# Altium schematic sheet Display.SchDoc
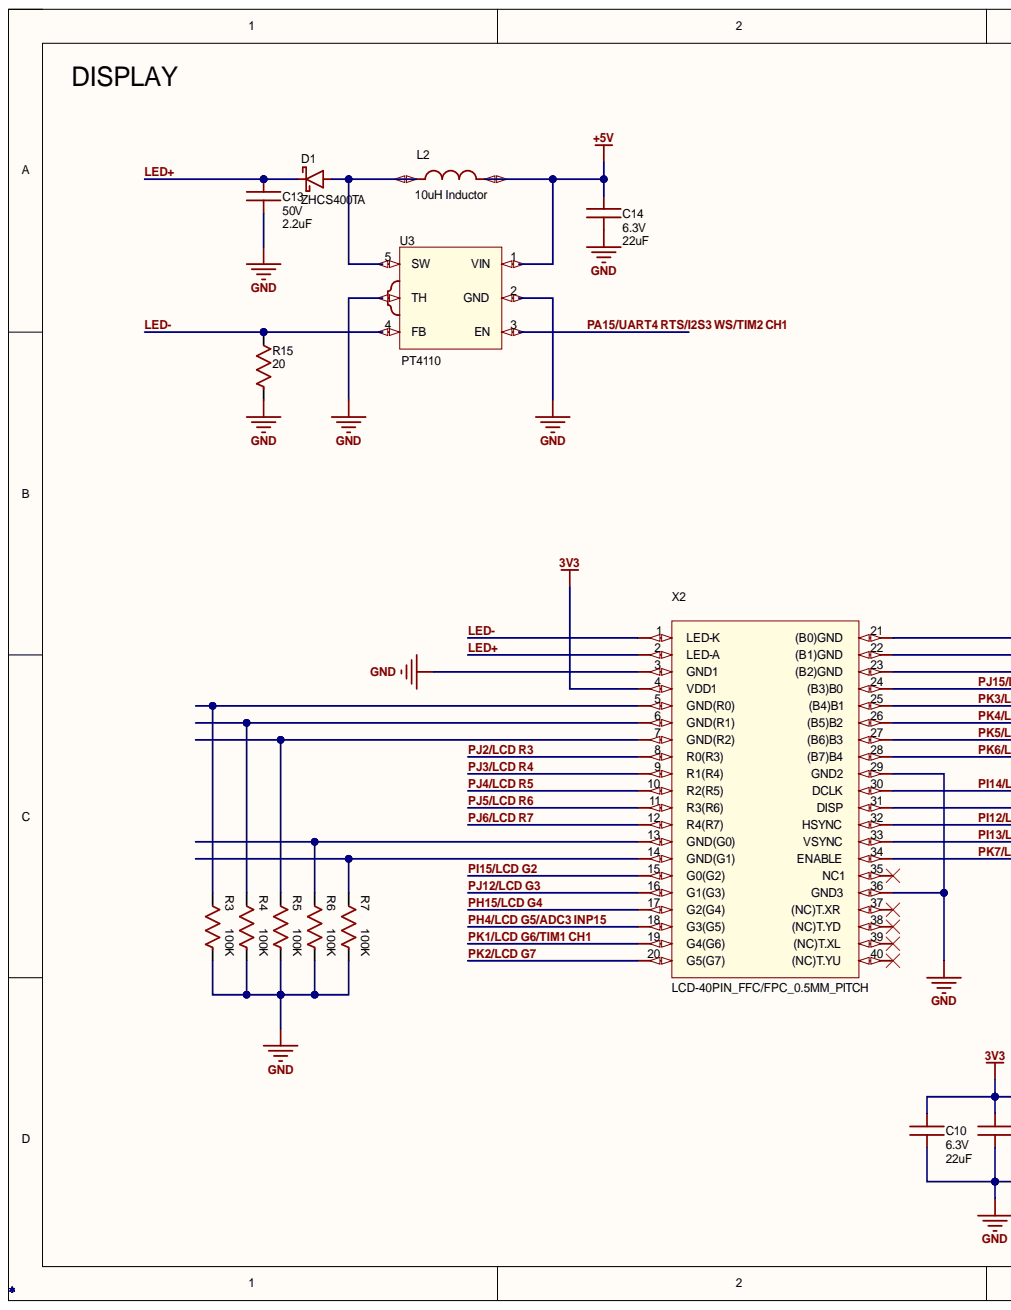
[diagram p0: Display.SchDoc, left]
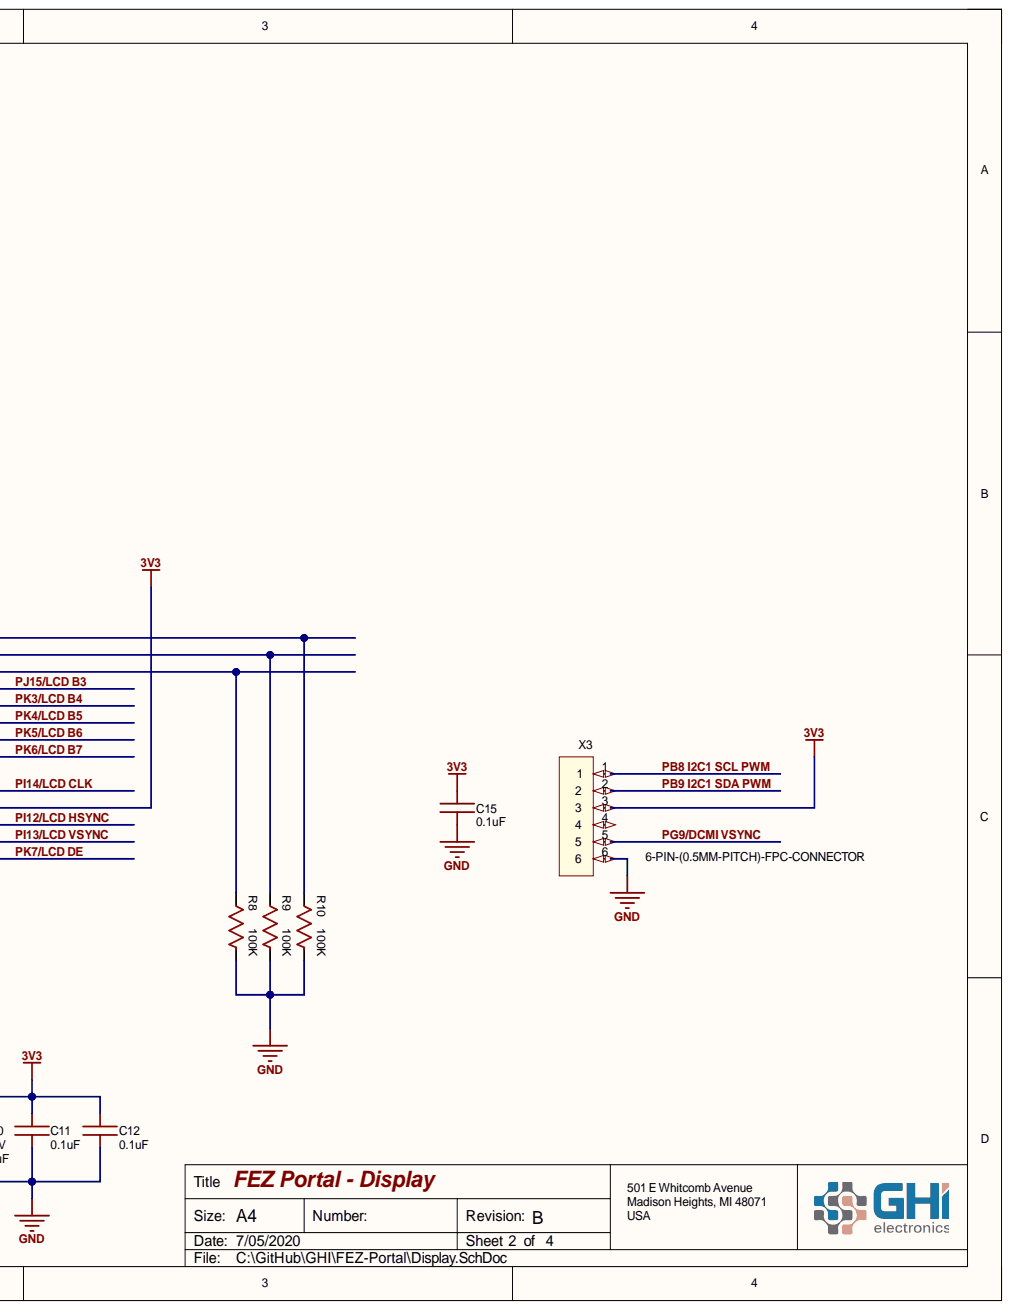
[diagram p1: Display.SchDoc, right]

## Parts
C10  value 22uF  Capacitance: 22uF  Voltage: 6.3V  [C0805 L]  (hidden comment: 0805 Cap 22uF)
C11  value 0.1uF  Capacitance: 0.1uF  [C0603 (1608 Metric)]  (hidden comment: 0603 Cap 0.1uF)
C12  value 0.1uF  Capacitance: 0.1uF  [C0603 (1608 Metric)]  (hidden comment: 0603 Cap 0.1uF)
C13  value 2.2uF  Capacitance: 2.2uF  Voltage: 50V  [C0805]  (hidden comment: 0805 Cap 2.2uF)
C14  value 22uF  Capacitance: 22uF  Voltage: 6.3V  [C0805]  (hidden comment: 0805 Cap 22uF)
C15  value 0.1uF  Capacitance: 0.1uF  [C0603 (1608 Metric)]  (hidden comment: 0603 Cap 0.1uF)
D1  ZHCS400TA  [SOD-323]
L2  (no value)  [NRS(TAIYO.YUDEN)]
R3  value 100K  Resistance: 100K  [R0603 (1608 Metric)]  (hidden comment: 0603 Res 100K)
R4  value 100K  Resistance: 100K  [R0603 (1608 Metric)]  (hidden comment: 0603 Res 100K)
R5  value 100K  Resistance: 100K  [R0603 (1608 Metric)]  (hidden comment: 0603 Res 100K)
R6  value 100K  Resistance: 100K  [R0603 (1608 Metric)]  (hidden comment: 0603 Res 100K)
R7  value 100K  Resistance: 100K  [R0603 (1608 Metric)]  (hidden comment: 0603 Res 100K)
R8  value 100K  Resistance: 100K  [R0603 (1608 Metric)]  (hidden comment: 0603 Res 100K)
R9  value 100K  Resistance: 100K  [R0603 (1608 Metric)]  (hidden comment: 0603 Res 100K)
R10  value 100K  Resistance: 100K  [R0603 (1608 Metric)]  (hidden comment: 0603 Res 100K)
R15  value 20  [R0603 (1608 Metric)]  (hidden comment: 0603 20)
U3  (no value)  [SOT89-5(DIODES)]
X2  LCD-40PIN_FFC/FPC_0.5MM_PITCH  [KISD04-40_T1_E]
X3  6-PIN-(0.5MM-PITCH)-FPC-CONNECTOR  [6-PIN-(0.5MM-PITCH)-FPC-CONNECTOR]

## Nets
3V3: C10.2 C11.2 C12.2 C15.2 X2.4(VDD1) X2.31(DISP) X3.3
+5V: C14.2 L2.2 U3.1(VIN)
GND: C10.1 C11.1 C12.1 C13.1 C14.1 C15.1 R3.1 R4.1 R5.1 R6.1 R7.1 R8.1 R9.1 R10.1 R15.1 U3.2(GND) U3.TH X2.3(GND1) X2.29(GND2) X2.36(GND3) X3.6
LED+: C13.2 D1.C X2.2(LED-A)
LED-: R15.2 U3.4(FB) X2.1(LED-K)
PA15/UART4 RTS/I2S3 WS/TIM2 CH1: U3.3(EN)
PB8 I2C1 SCL PWM: X3.1
PB9 I2C1 SDA PWM: X3.2
PG9/DCMI VSYNC: X3.5
PH4/LCD G5/ADC3 INP15: X2.18(G3(G5))
PH15/LCD G4: X2.17(G2(G4))
PI12/LCD HSYNC: X2.32(HSYNC)
PI13/LCD VSYNC: X2.33(VSYNC)
PI14/LCD CLK: X2.30(DCLK)
PI15/LCD G2: X2.15(G0(G2))
PJ2/LCD R3: X2.8(R0(R3))
PJ3/LCD R4: X2.9(R1(R4))
PJ4/LCD R5: X2.10(R2(R5))
PJ5/LCD R6: X2.11(R3(R6))
PJ6/LCD R7: X2.12(R4(R7))
PJ12/LCD G3: X2.16(G1(G3))
PJ15/LCD B3: X2.24((B3)B0)
PK1/LCD G6/TIM1 CH1: X2.19(G4(G6))
PK2/LCD G7: X2.20(G5(G7))
PK3/LCD B4: X2.25((B4)B1)
PK4/LCD B5: X2.26((B5)B2)
PK5/LCD B6: X2.27((B6)B3)
PK6/LCD B7: X2.28((B7)B4)
PK7/LCD DE: X2.34(ENABLE)
NetD1_A: D1.A L2.1 U3.5(SW)
NetR3_2: R3.2 X2.5(GND(R0))
NetR4_2: R4.2 X2.6(GND(R1))
NetR5_2: R5.2 X2.7(GND(R2))
NetR6_2: R6.2 X2.13(GND(G0))
NetR7_2: R7.2 X2.14(GND(G1))
NetR8_2: R8.2 X2.23((B2)GND)
NetR9_2: R9.2 X2.22((B1)GND)
NetR10_2: R10.2 X2.21((B0)GND)

Not connected: X2 35(NC1) 37((NC)T.XR) 38((NC)T.YD) 39((NC)T.XL) 40((NC)T.YU); X3 4

## Library data of the parts
C10 C14 = 0805 Cap 22uF: 22uF ±20% 6.3V X5R 0805 Multilayer Ceramic Capacitors MLCC - SMD/SMT RoHS; Mfr.Part #: CL21A226MQCLRNC; Category: Ceramic; Component Kind: Standard; LCSC Part #: C307530; Package: 0805; Tolerance: ±20%; Library Name: GHI-Passives.SchLib
C11 C12 C15 = 0603 Cap 0.1uF: 0.1uF ±5% 50V X7R 0603 Multilayer Ceramic Capacitors MLCC - SMD/SMT RoHS; Mfr.Part #: CL10B104JB8NNNC; Category: Ceramic; Component Kind: Standard; Digikey: 1276-1033-1-ND; LCSC Part #: C24452; Package: 0603; Tolerance: ±5%; Voltage: 50V; Library Name: GHI-Passives.SchLib
C13 = 0805 Cap 2.2uF: 2.2uF ±10% 50V X5R 0805 Multilayer Ceramic Capacitors MLCC - SMD/SMT RoHS; Mfr.Part #: CL21A225KBQNNNE; Category: Ceramic; Component Kind: Standard; LCSC Part #: C377773; Package: 0805; Tolerance: ±10%; Library Name: GHI-Passives.SchLib
D1 = 400mA SOD-323 Schottky: 40V 400mA 500mV @ 400mA SOD-323 Schottky Barrier Diodes (SBD) RoHS; Manufacturer: Diodes Incorporated; Component Kind: Standard; Component Type: Diode; LCSC Part #: C211405; Mfr.Part #: ZHCS400TA; Package: SOD-323; Value: ZHCS400TA; Library Name: GHI-Semiconductors.SchLib
L2 = INDUCTOR-(TAIYO-YUDEN)-NRS5030: 10uH ±20% 1.7A 70mΩ SMD,4.9x4.9x3.0mm Power Inductors RoHS; Manufacturer: Taiyo Yuden; Mfr.Part #: NRS5030T100MMGJ; Value: NRS5030T100MMGJ; Component Kind: Standard; LCSC Part #: C222939; Package: SMD,4.9x4.9x3.0mm; Library Name: GHI-Power.SchLib
R3-R10 = 0603 Res 100K: 100K ±1% 1/10W ±100ppm/℃ 0603 Chip Resistor - Surface Mount RoHS; Manufacturer: YAGEO; Mfr.Part #: RC0603FR-07100KL; Voltage Rating: *V; Component Kind: Standard; LCSC Part #: C14675; Package: 0603; Power Rating: 1/10W; Resistance Tolerance: ±1%; Library Name: GHI-Passives.SchLib
R15 = 0603 Res 20: 20 ±1% 1/10W ±100ppm/℃ 0603 Chip Resistor - Surface Mount RoHS; Manufacturer: YAGEO; Mfr.Part #: RC0603FR-0720RL; Voltage Rating: *V; Component Kind: Standard; LCSC Part #: C125758; Package: 0603; Power Rating: 1/10W; Resistance: 20; Resistance Tolerance: ±1%; Library Name: GHI-Passives.SchLib
U3 = PT4110: SOT89-5 LED Drivers RoHS; Manufacturer: PowTech(CR-PowTech(Shanghai)); Mfr.Part #: PT4110E89E; Value: PT4110E89E; Component Kind: Standard; LCSC Part #: C93793; Package: SOT89-5; Library Name: GHI-Power.SchLib
X2 = LCD-40PIN_FFC/FPC_0.5MM_PITCH: GateName_1: G$1; SymbolName_1: LCD.RIBBON-40PIN_FFC/FPC_0.5MM_PITCH; Component Kind: Standard; DeviceSetName: LCD-40PIN_FFC/FPC_0.5MM_PITCH; Library Name: GHI-Connectors.SchLib; LibraryName: GHI-VisualComponents
X3 = 6-PIN-(0.5MM-PITCH)-FPC-CONNECTOR: GateName_1: G$1; SymbolName_1: 6-PINS(1X6); Component Kind: Standard; DeviceSetName: 6-PIN-(0.5MM-PITCH)-FPC-CONNECTOR; Library Name: GHI-Connectors.SchLib; LibraryName: GHI-Connectors
